# Altium schematic sheet POWER.SchDoc(POWER)
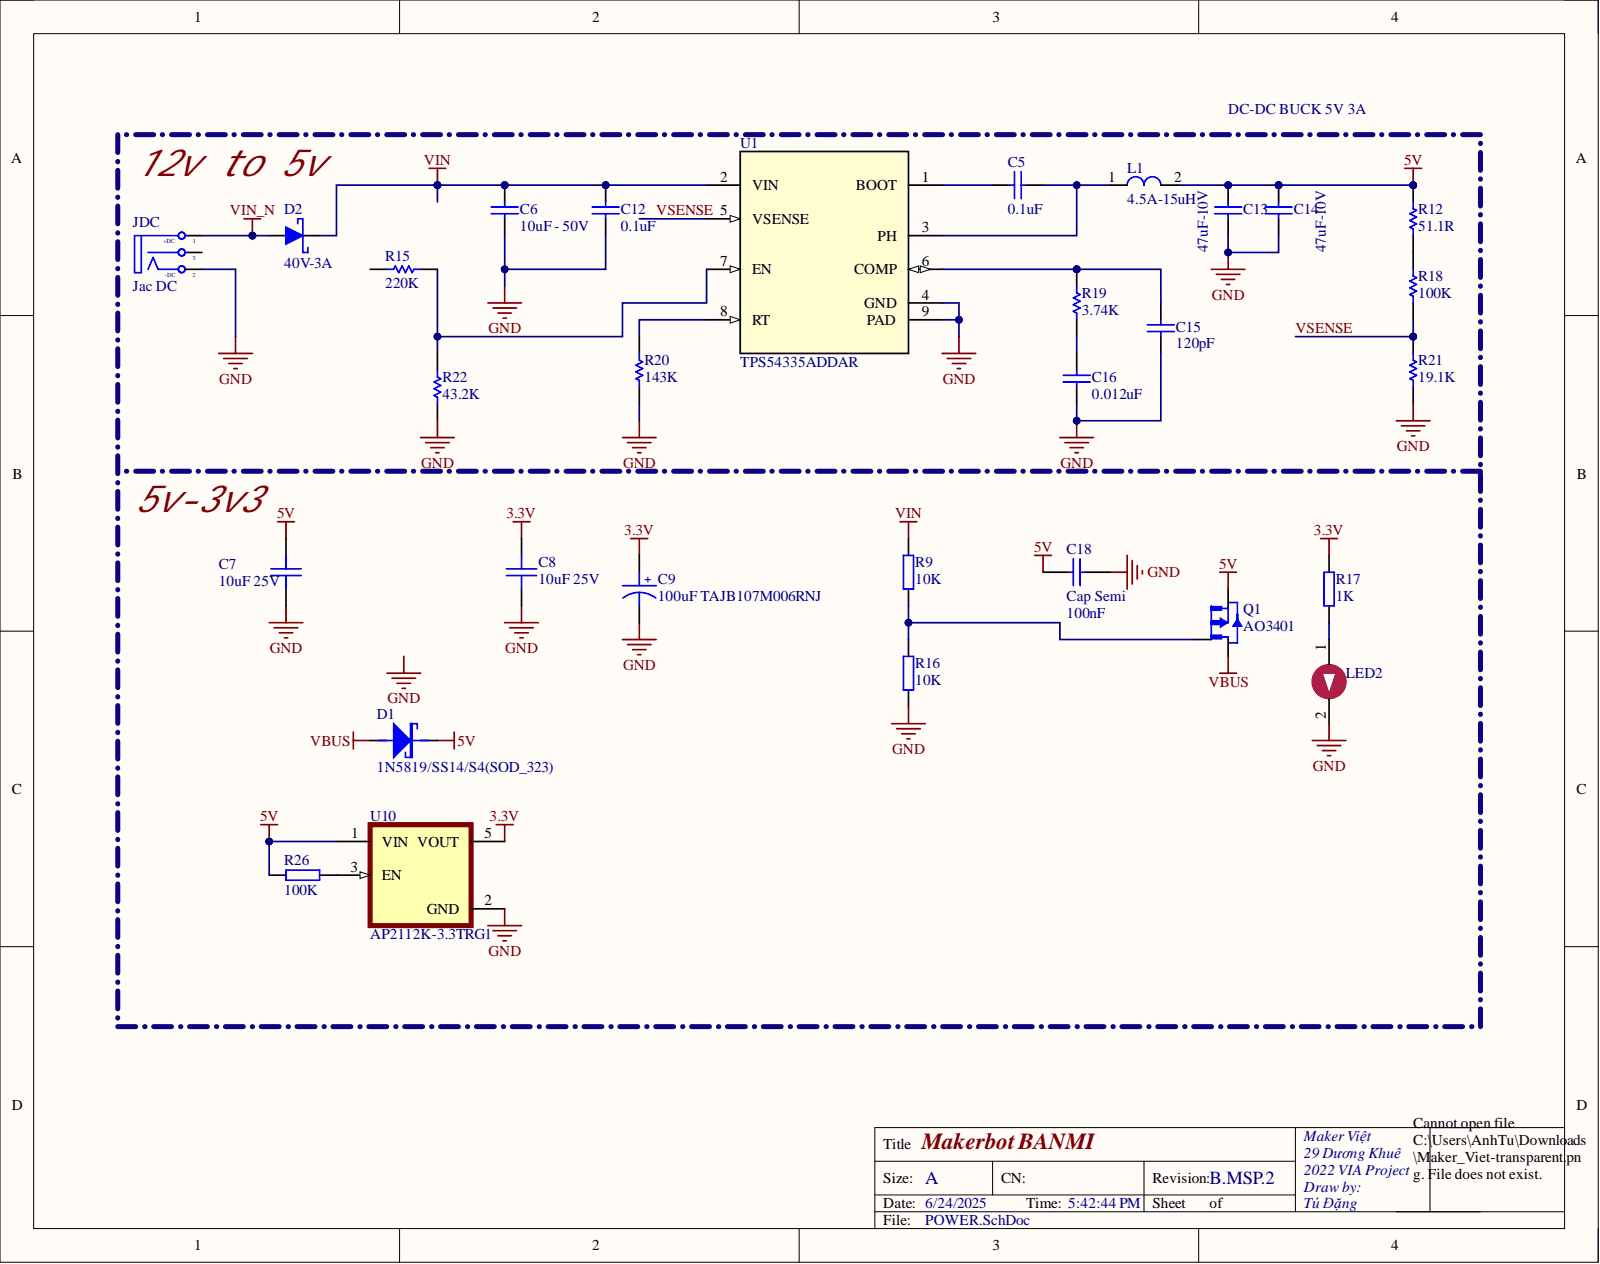
[diagram p0: POWER.SchDoc]

## Parts
C5  0.1uF
C6  10uF - 50V
C7  10uF 25V
C8  10uF 25V
C9  100uF TAJB107M006RNJ
C12  0.1uF
C13  47uF-10V
C14  47uF-10V
C15  120pF
C16  0.012uF
C18  Cap Semi  value 100nF
D1  1N5819/SS14/S4(SOD_323)
D2  40V-3A
JDC  Jac DC
L1  4.5A-15uH
LED2  LED3  (value not drawn)
Q1  AO3401
R9  value 10K  (hidden comment: Resistor)
R12  51.1R
R15  220K
R16  value 10K  (hidden comment: Resistor)
R17  value 1K  (hidden comment: Resistor)
R18  100K
R19  3.74K
R20  143K
R21  19.1K
R22  43.2K
R26  value 100K  (hidden comment: Resistor)
U1  TPS54335ADDAR
U10  AP2112K-3.3TRG1

## Nets
3.3V: C8.1 C9.1 R17.1 U10.5(VOUT)
5V: C7.1 C13.2 C14.2 C18.1 D1.2 L1.2 Q1.2 R12.1 R26.2 U10.1(VIN)
GND: C6.1 C7.2 C8.2 C9.2 C12.1 C13.1 C14.1 C15.1 C16.1 C18.2 JDC.2 LED2.2 R16.1 R20.2 R21.2 R22.2 U1.4(GND) U1.9(PAD) U10.2(GND)
VBUS: D1.1 Q1.3
VIN: C6.2 C12.2 D2.C R9.2 U1.2(VIN)
VIN_N: D2.A JDC.1
VSENSE: R18.2 R21.1 U1.5(VSENSE)
NetC5_1: C5.1 L1.1 U1.3(PH)
NetC5_2: C5.2 U1.1(BOOT)
NetC15_2: C15.2 R19.1 U1.6(COMP)
NetC16_2: C16.2 R19.2
NetLED2_1: LED2.1 R17.2
NetQ1_1: Q1.1 R9.1 R16.2
NetR12_2: R12.2 R18.1
NetR15_2: R15.2 R22.1 U1.7(EN)
NetR20_1: R20.1 U1.8(RT)
NetR26_1: R26.1 U10.3(EN)

Not connected: JDC 3; R15 1

## Library data of the parts
C5 C12 = C0603X7R104K500NT: CAP CER 0.1UF 50V X7R 0603; Manufacture: Hottech; Database Table Name: Components; Detailed Value: 0.1uF - 50V - 0603; PROJECT: KHO; Category: Capacitor; Component Kind: Standard; Mounting type: SMD; Partnumber: C0603X7R104K500NT; Value: 0.1uF; Creator: ThangND; Library Name: MKP_lib.DbLib
C6 = C1206X5R106K500NT: CAP CER 10uF 50V X5R 1206; Manufacture: Hottech; Database Table Name: Components; Detailed Value: 10uF - 50V - 1206; PROJECT: KHO; Category: Capacitor; Component Kind: Standard; Mounting type: SMD; Partnumber: C1206X5R106K500NT; Value: 10uF - 50V; Creator: ThangND; Library Name: MKP_lib.DbLib
C7 C8 = SMT_C_0805: Surface mount capacitor 0805 10uF; Component Kind: Standard; Library Name: NODEMCU_DEVKIT_V1.0.IntLib
C9 = SMT_C_Tantalum_B: Capacitor, SM Tantalum; Body 3.5 x 2.8 mm (LxW typ); Component Kind: Standard; Library Name: NODEMCU_DEVKIT_V1.0.IntLib
C13 C14 = CL31A476MPHNNNE: CAP CER 47UF 10V X5R 1206; Manufacture: Samsung Electro-Mechanics; Database Table Name: Components; Category: Capacitor; Component Kind: Standard; Mounting type: SMD; Partnumber: CL31A476MPHNNNE; Value: 47uF-10V; Creator: Tunn; Library Name: MKP_lib.DbLib
C15 = CL10C121JB8NNNC: CAP CER 120PF 50V C0G/NP0 0603; Manufacture: Samsung Electro-MechanicsSamsung Electro-Mechanics; Database Table Name: Components; Category: Capacitor; Component Kind: Standard; Mounting type: SMD; Partnumber: CL10C121JB8NNNC; Value: 120pF; Creator: Tunn; Library Name: MKP_lib.DbLib
C16 = CC0603KRX7R8BB123: CAP CER 0.012UF 25V X7R 0603; Manufacture: YAGEO; Database Table Name: Components; Category: Capacitor; Component Kind: Standard; Mounting type: SMD; Partnumber: CC0603KRX7R8BB123; Value: 0.012uF; Creator: Tunn; Library Name: MKP_lib.DbLib
C18 = CAP-SM-0603-X7R-100nF: CAP SM 0603 X7R 100nF 10%; Component Kind: Standard; Library Name: electronpowered.SchLib; Supplier 1: Digi-Key; Supplier 2: Farnell; Supplier Part Number 1: 490-3167-1-ND; Supplier Part Number 2: 1775994RL; Tolerance: ±10%
D1 = SMT_DIODE_SCHOTTKY_SOD_323: Surface mount schottky diode SOD-323(0805) Package; Component Kind: Standard; Library Name: NODEMCU_DEVKIT_V1.0.IntLib
D2 = SS34A: Database Table Name: Components; Category: Diode; Component Kind: Standard; Detailed Value: 40V-3A; Mounting type: SMD; Partnumber: SS34A; Value: 40V-3A; Creator: Tunn; Library Name: MKP_lib.DbLib
JDC = DCIN: Jac DC input; Component Kind: Standard; Library Name: My Library.SchLib; Value: Jac DC
L1 = SWRH1207B-150MT: 4.5A 15uH ±20% SMD Power Inductors ROHS; Manufacture: Sunlord; Database Table Name: Components; Category: Inductor; Component Kind: Standard; Detailed Value: 4.5A-15uH; Mounting type: Surface Mount; Partnumber: SWRH1207B-150MT; Tolerance: ±20%; Value: 4.5A-15uH; Creator: Manhpd; Library Name: MKP_lib.DbLib
LED2 = LED0805: LED SMD 0805; Component Kind: Standard; Library Name: My Library.SchLib
Q1 = AO3401: P-Channel 30V 4A _Ta_ 1.4W _Ta_ Surface Mount SOT-23-3; MF: Alpha & Omega Semiconductor; Availability: Unavailable; Component Kind: Standard; Library Name: AO3401.IntLib; MP: AO3401; Package: SOT-23-3 Alpha &amp; Omega Semiconductor; Price: None; SnapEDA_Link: https://www.snapeda.com/parts/AO3401/Alpha%20%26%20Omega%20Semiconductor/view-part/4592622/?ref=snap
R9 R16 = RES-SM-0603-10K: RES SM 0603 10K; Component Kind: Standard; Library Name: electronpowered.SchLib; Supplier 1: Farnell; Supplier 2: Digi-Key; Supplier Part Number 1: 9330399; Supplier Part Number 2: 541-10.0KHCT-ND
R12 = RC0603FR-0751R1L: RES 51.1 OHM 1% 1/10W 0603; Manufacture: YAGEO; Database Table Name: Components; Category: Resistor; Component Kind: Standard; Mounting type: SMD; Partnumber: RC0603FR-0751R1L; Value: 51.1R; Creator: Tunn; Library Name: MKP_lib.DbLib
R15 = RC0603FR-07220KL: 220kΩ ±1% 0.1W ±100ppm/℃ 0603 Chip Resistor - Surface Mount RoHS; Manufacture: Yageo; Database Table Name: Components; Category: Resistor; Component Kind: Standard; Mounting type: SMD; Partnumber: RC0603FR-07220KL; Value: 220K; Creator: Tunn; Library Name: MKP_lib.DbLib
R17 = RES-SM-0603-100K: RES SM 0603 1K; Component Kind: Standard; Library Name: electronpowered.SchLib; Supplier 1: Farnell; Supplier 2: Digi-Key; Supplier Part Number 1: 1344361; Supplier Part Number 2: 541-100KHCT-ND
R18 = RI0603L1003FT: RES SMD 100K OHM 1% 1/10W 0603; Manufacture: Hottech; Database Table Name: Components; Detailed Value: 100K - 1% - 1/10W; PROJECT: KHO; Category: Resistor; Component Kind: Standard; Mounting type: SMD; Partnumber: RI0603L1003FT; Value: 100K; Creator: anhdn; Library Name: MKP_lib.DbLib
R19 = RC0603FR-073K74L: 3.74 kOhms ±1% 0.1W, 1/10W Chip Resistor 0603 (1608 Metric) Moisture Resistant Thick Film; Manufacture: YAGEO; Database Table Name: Components; Category: Resistor; Component Kind: Standard; Mounting type: SMD; Partnumber: RC0603FR-073K74L; Value: 3.74K; Creator: Tunn; Library Name: MKP_lib.DbLib
R20 = RC0603FR-07143KL: RES 143K OHM 1% 1/10W 0603; Manufacture: YAGEO; Database Table Name: Components; Category: Resistor; Component Kind: Standard; Mounting type: SMD; Partnumber: RC0603FR-07143KL; Value: 143K; Creator: Tunn; Library Name: MKP_lib.DbLib
R21 = RC0603FR-0719K1L: RES 19.1K OHM 1% 1/10W 0603; Manufacture: YAGEO; Database Table Name: Components; Category: Resistor; Component Kind: Standard; Mounting type: SMD; Partnumber: RC0603FR-0719K1L; Value: 19.1K; Creator: Tunn; Library Name: MKP_lib.DbLib
R22 = RC0603FR-0743K2L: RES 43.2K OHM 1% 1/10W 0603; Manufacture: YAGEO; Database Table Name: Components; Category: Resistor; Component Kind: Standard; Mounting type: SMD; Partnumber: RC0603FR-0743K2L; Value: 43.2K; Creator: Tunn; Library Name: MKP_lib.DbLib
R26 = RES-SM-0603-100K: RES SM 0603 100K; Component Kind: Standard; Library Name: electronpowered.SchLib; Supplier 1: Farnell; Supplier 2: Digi-Key; Supplier Part Number 1: 1344361; Supplier Part Number 2: 541-100KHCT-ND
U1 = TPS54335ADDAR: IC REG BUCK ADJUSTABLE 3A 8SOPWR; Manufacture: Texas Instruments; Database Table Name: Components; Category: IC; Component Kind: Standard; Mfr_Name: Texas Instruments; Mounting type: SMD; Partnumber: TPS54335ADDAR; RefDes: RefDes; TYPE: TYPE; Value: TPS54335ADDAR; Creator: Tunn; Library Name: MKP_lib.DbLib
U10 = AP2112K-3.3TRG1: Linear Voltage Regulator IC 1 Output 600mA SOT-25; MF: Diodes Inc.; Component Kind: Standard; Digi-Key_Part_Number: AP2112K-3.3TRG1DICT-ND; Digi-Key_Purchase_URL: https://www.digikey.com.au/product-detail/en/diodes-incorporated/AP2112K-3.3TRG1/AP2112K-3.3TRG1DICT-ND/4505257?utm_source=snapeda&utm_medium=aggregator&utm_campaign=symbol; Library Name: AP2112K-3.3TRG1.IntLib; MP: AP2112K-3.3TRG1; Package: SOT-753 Diodes Inc.; SnapEDA_Link: https://www.snapeda.com/parts/AP2112K-3.3TRG1/Diodes%20Inc./view-part/454988/?ref=snap
